# Altium schematic sheet 2 - Microcontroller.SchDoc
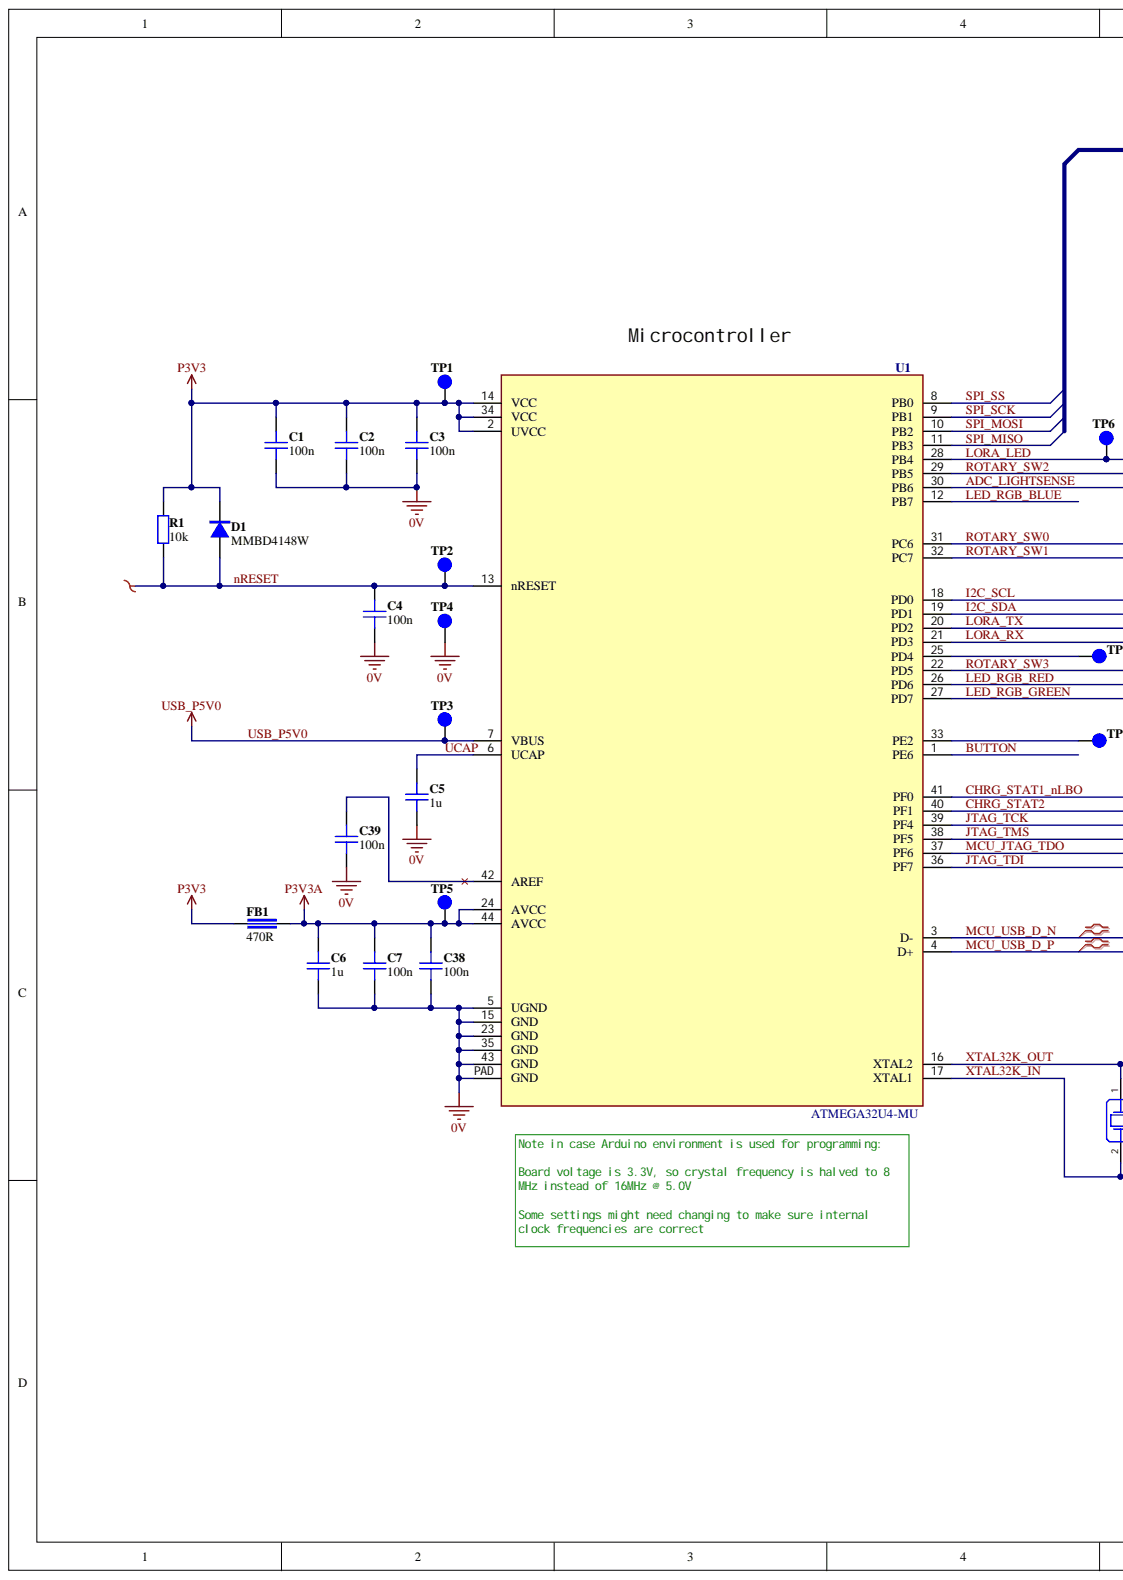
[diagram p0: 2 - Microcontroller.SchDoc, left]
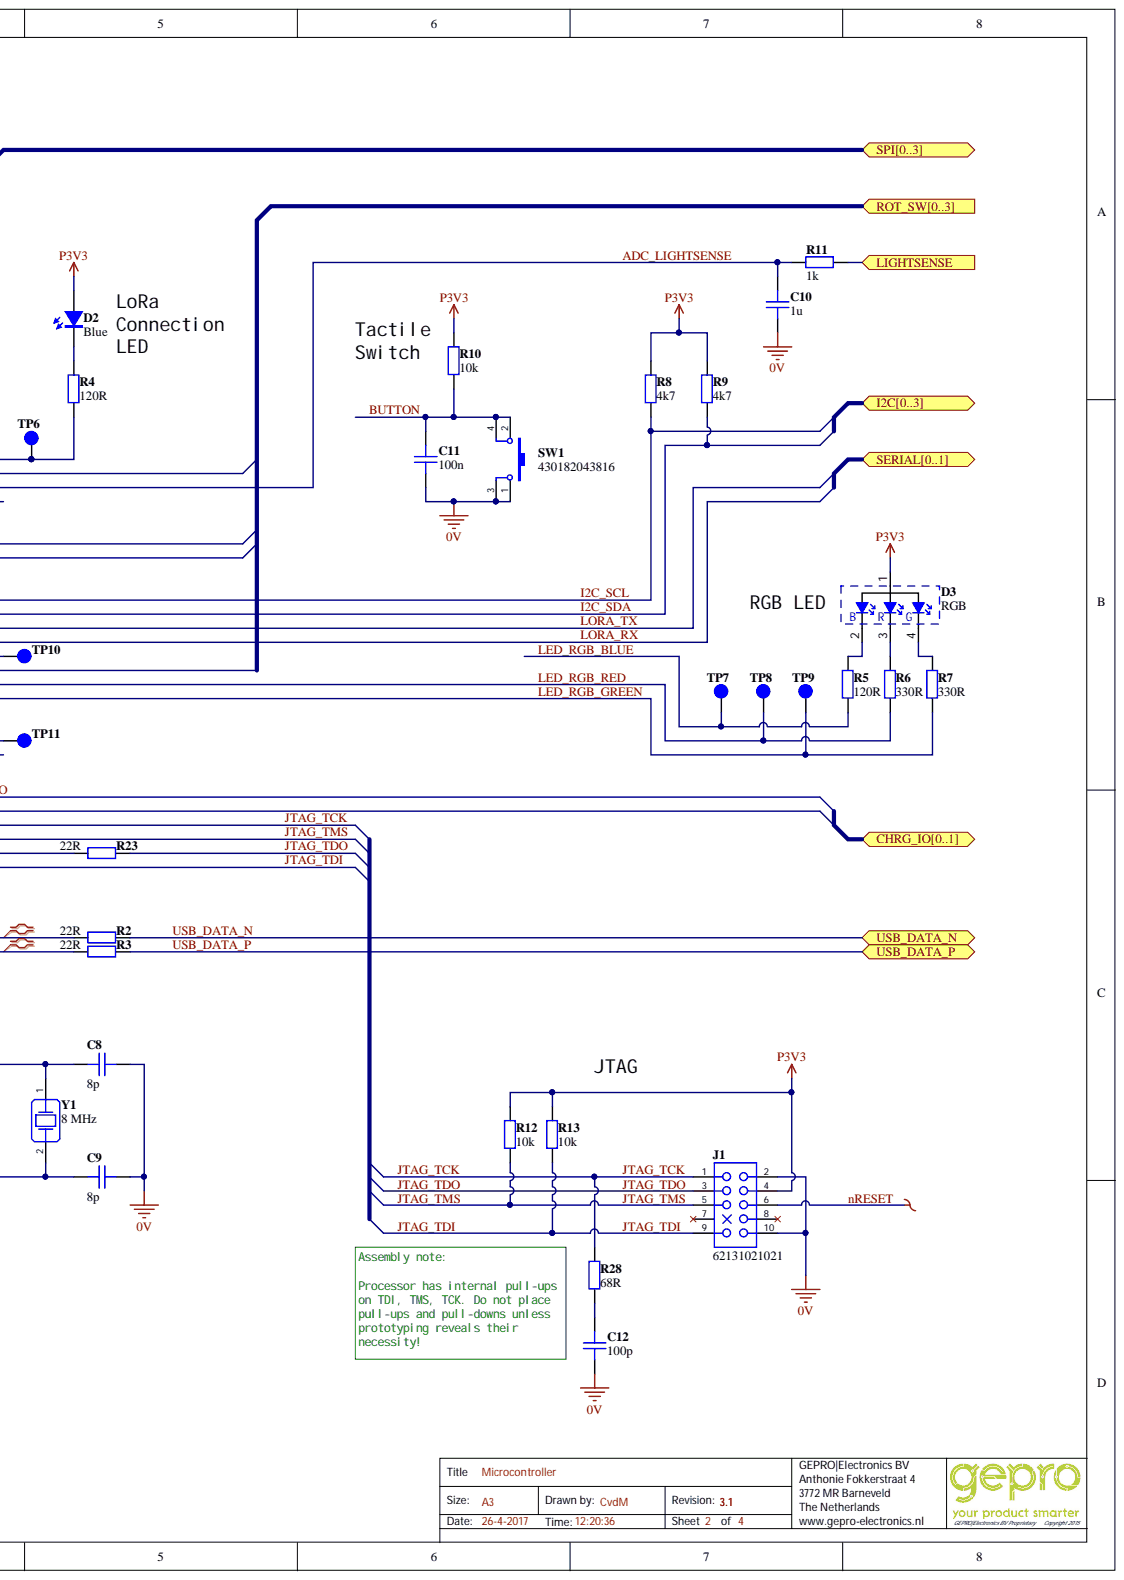
[diagram p1: 2 - Microcontroller.SchDoc, right]

## Parts
C1  100n  [CAPC1608X90N]
C2  100n  [CAPC1608X90N]
C3  100n  [CAPC1608X90N]
C4  100n  [CAPC1608X90N]
C5  1u  [CAPC1608X95N]
C6  1u  [CAPC1608X95N]
C7  100n  [CAPC1608X90N]
C8  8p  [CAPC1608X90N]
C9  8p  [CAPC1608X90N]
C10  1u  [CAPC1608X95N]
C11  100n  [CAPC1608X90N]
C12  100p  [CAPC1608X95N]
C38  100n  [CAPC1608X90N]
C39  100n  [CAPC1608X90N]
D1  MMBD4148W  [SODFL370X55-2N_DiodesInc_SOD123]
D2  Blue  [LEDSC80X160X80-2N_WurthElektronik_WL-SMCW_BLUE]
D3  RGB  Component Subtype: LED  [WURTH_WL-SFTW_150141M173100]
FB1  470R  [FB1608X100N]
J1  62131021021  [WurthElektronik_WR-PHD_62131021021]
R1  10k  [RESC1608X50N]
R2  22R  [RESC1608X50N]
R3  22R  [RESC1608X50N]
R4  120R  [RESC1608X50N]
R5  120R  [RESC1608X50N]
R6  330R  [RESC1608X50N]
R7  330R  [RESC1608X50N]
R8  4k7  [RESC1608X50N]
R9  4k7  [RESC1608X50N]
R10  10k  [RESC1608X50N]
R11  1k  [RESC1608X50N]
R12  10k  [RESC1608X50N]
R13  10k  [RESC1608X50N]
R23  22R  [RESC1608X50N]
R28  68R  [RESC1608X50N]
SW1  430182043816  [WurthElektronik_WS-TASV_4301x2xxx8x6]
TP1  Comment  [Testpoint_PAD_DIA_1mm]  (value not drawn)  (no BOM)
TP2  Comment  [Testpoint_PAD_DIA_1mm]  (value not drawn)  (no BOM)
TP3  Comment  [Testpoint_PAD_DIA_1mm]  (value not drawn)  (no BOM)
TP4  Comment  [Testpoint_PAD_DIA_1mm]  (value not drawn)  (no BOM)
TP5  Comment  [Testpoint_PAD_DIA_1mm]  (value not drawn)  (no BOM)
TP6  Comment  [Testpoint_PAD_DIA_1mm]  (value not drawn)  (no BOM)
TP7  Comment  [Testpoint_PAD_DIA_1mm]  (value not drawn)  (no BOM)
TP8  Comment  [Testpoint_PAD_DIA_1mm]  (value not drawn)  (no BOM)
TP9  Comment  [Testpoint_PAD_DIA_1mm]  (value not drawn)  (no BOM)
TP10  Comment  [Testpoint_PAD_DIA_1mm]  (value not drawn)  (no BOM)
TP11  Comment  [Testpoint_PAD_DIA_1mm]  (value not drawn)  (no BOM)
U1  ATMEGA32U4-MU  Component Type: Microcontroller  [QFN50P700X700X100-45T520X520N_Atmel_QFN44]
Y1  8 MHz  [NDK_NX5032GA]

## Ports
CHRG_IO0, CHRG_IO1, CHRG_IO[0..1], I2C0, I2C1, I2C2, I2C3, I2C[0..3], LIGHTSENSE, ROT_SW0, ROT_SW1, ROT_SW2, ROT_SW3, ROT_SW[0..3], SERIAL0, SERIAL1, SERIAL[0..1], SPI0, SPI1, SPI2, SPI3, SPI[0..3], USB_DATA_N, USB_DATA_P

## Nets
0V: C1.2 C2.2 C3.2 C4.2 C5.2 C6.2 C7.2 C8.2 C9.2 C10.2 C11.2 C12.2 C38.2 C39.2 J1.2 J1.10 SW1.1 SW1.3 TP4.1 U1.5(UGND) U1.15(GND) U1.23(GND) U1.35(GND) U1.43(GND) U1.PAD(GND)
P3V3: C1.1 C2.1 C3.1 D1.C D2.A D3.1 FB1.1 J1.4 R1.1 R8.1 R9.1 R10.1 R12.1 R13.1 TP1.1 U1.2(UVCC) U1.14(VCC) U1.34(VCC)
P3V3A: C6.1 C7.1 C38.1 FB1.2 TP5.1 U1.24(AVCC) U1.44(AVCC)
ADC_LIGHTSENSE: C10.1 R11.1 U1.30(PB6)
BUTTON: C11.1 R10.2 SW1.2 SW1.4 U1.1(PE6)
CHRG_STAT1_nLBO: U1.41(PF0)
CHRG_STAT2: U1.40(PF1)
I2C_SCL: R8.2 U1.18(PD0)
I2C_SDA: R9.2 U1.19(PD1)
JTAG_TCK: J1.1 R28.1 U1.39(PF4)
JTAG_TDI: J1.9 R13.2 U1.36(PF7)
JTAG_TDO: J1.3 R23.2
JTAG_TMS: J1.5 R12.2 U1.38(PF5)
LED_RGB_BLUE: R5.2 TP7.1 U1.12(PB7)
LED_RGB_GREEN: R7.2 TP9.1 U1.27(PD7)
LED_RGB_RED: R6.2 TP8.1 U1.26(PD6)
LIGHTSENSE: R11.2 ; port LIGHTSENSE
LORA_LED: R4.2 TP6.1 U1.28(PB4)
LORA_RX: U1.21(PD3)
LORA_TX: U1.20(PD2)
MCU_JTAG_TDO: R23.1 U1.37(PF6)
MCU_USB_D_N: R2.1 U1.3(D-)
MCU_USB_D_P: R3.1 U1.4(D+)
nRESET: C4.1 D1.A J1.6 R1.2 TP2.1 U1.13(nRESET)
ROTARY_SW0: U1.31(PC6)
ROTARY_SW1: U1.32(PC7)
ROTARY_SW2: U1.29(PB5)
ROTARY_SW3: U1.22(PD5)
SPI_MISO: U1.11(PB3)
SPI_MOSI: U1.10(PB2)
SPI_SCK: U1.9(PB1)
SPI_SS: U1.8(PB0)
UCAP: C5.1 U1.6(UCAP)
USB_DATA_N: R2.2 ; port USB_DATA_N
USB_DATA_P: R3.2 ; port USB_DATA_P
USB_P5V0: TP3.1 U1.7(VBUS)
XTAL32K_IN: C9.1 U1.17(XTAL1) Y1.2
XTAL32K_OUT: C8.1 U1.16(XTAL2) Y1.1
NetC12_1: C12.1 R28.2
NetC39_1: C39.1 U1.42(AREF)
NetD2_C: D2.C R4.1
NetD3_2: D3.2 R5.1
NetD3_3: D3.3 R6.1
NetD3_4: D3.4 R7.1
NetTP10_1: TP10.1 U1.25(PD4)
NetTP11_1: TP11.1 U1.33(PE2)
CHRG_IO[0..1]:
I2C[0..3]:
N00022:
ROT_SW[0..3]:
SERIAL[0..1]:
SPI[0..3]:

Not connected: J1 7 8

## Library data of the parts
C1-C4 C7 C11 C38 C39 = CAP_MLCC_1608_X7R_100nF_50V: Capacitor MLCC 0603 (1608 Metric) X7R 50V 100nF 10%; Manufacturer: No Preference; Manufacturer Part Number: Select according to description parameters; Package Technology: SMT; Polarized?: No; Capacitance: 100nF; Component Kind: Standard; Component Subtype: MLCC; Component Type: Capacitor; Dielectric Type: X7R; Operating Temperature Range: -55°C ~ 125°C; Package / Case: 0603 (1608 Metric); Pin Count: 2; RoHS Compliant?: Yes; Tolerance: ±10%; Voltage Rating: 50V; Library Name: KISS_IoT.SchLib
C5 C6 C10 = CAP_MLCC_1608_X7R_1u_10V: Capacitor MLCC 0603 (1608 Metric) X7R 10V 1uF 10%; Manufacturer: No Preference; Manufacturer Part Number: Select according to description parameters; Capacitance: 1µF; Package Technology: SMT; Polarized?: No; Component Kind: Standard; Component Subtype: MLCC; Component Type: Capacitor; Dielectric Type: X7R; Operating Temperature Range: -55°C ~ 125°C; Package / Case: 0603 (1608 Metric); Pin Count: 2; RoHS Compliant?: Yes; Tolerance: ±10%; Voltage Rating: 10V; Library Name: KISS_IoT.SchLib
C8 C9 = CAP_MLCC_1608_C0G_8pF_16V: Capacitor MLCC 0603 (1608 Metric) C0G (NP0) 16V 8pF 5%; Manufacturer: No Preference; Manufacturer Part Number: Select according to description parameters; Package Technology: SMT; Polarized?: No; Capacitance: 8pF; Component Kind: Standard; Component Subtype: MLCC; Component Type: Capacitor; Dielectric Type: C0G (NP0); Operating Temperature Range: -55°C ~ 125°C; Package / Case: 0603 (1608 Metric); Pin Count: 2; RoHS Compliant?: Yes; Tolerance: ±5%; Voltage Rating: 16V; Library Name: KISS_IoT.SchLib
C12 = CAP_MLCC_1608_X7R_100p_50V: Capacitor MLCC 0603 (1608 Metric) X7R 50V 100pF 10%; Manufacturer: No Preference; Manufacturer Part Number: Select according to description parameters; Package Technology: SMT; Polarized?: No; Capacitance: 100pF; Component Kind: Standard; Component Subtype: MLCC; Component Type: Capacitor; Dielectric Type: X7R; Operating Temperature Range: -55°C ~ 125°C; Package / Case: 0603 (1608 Metric); Pin Count: 2; RoHS Compliant?: Yes; Tolerance: ±10%; Voltage Rating: 50V; Library Name: KISS_IoT.SchLib
D1 = MMBD4148W: Diode Small Signal Vf=1.25V Vr=100V Id=150mA; Manufacturer: Diodes Incorporated; Manufacturer Part Number: 1N4148W-13-F; Current - Average Rectified (Io) (per Diode): 150mA; Current - Reverse Leakage @ Vr: 1µA @ 75V; Package Technology: SMT; Polarized?: Yes; Voltage - DC Reverse (Vr) (Max): 100V; Voltage - Forward (Vf) (Max) @ If: 1.25V @ 150mA; Component Kind: Standard; ComponentLink1Description: Data Sheet; ComponentLink1URL: http://www.diodes.com/_files/datasheets/ds30086.pdf; Component Subtype: Small Signal; Component Type: Diode; Operating Temperature Range: -65°C ~ 150°C; Package / Case: SOD-123; Pin Count: 2; RoHS Compliant?: Yes; Library Name: KISS_IoT.SchLib
D2 = 150060BS75000: LED 1608 3.2Vf 470nm Blue; Manufacturer: Würth Elektronik; Forward Voltage (Vf) (Typ): 3.2V; Lens Style/Size: Rectangle with Flat Top, 1.10mm x 0.70mm; Milicandella Rating: 145mcd; Package Technology: SMT; Polarized?: Yes; Color: Blue; Component Kind: Standard; ComponentLink1Description: Data Sheet; ComponentLink1URL: http://katalog.we-online.de/led/datasheet/150060BS75000.pdf; Component Subtype: LED; Component Type: Opto-electronics; Manufacturer Part Number: 150060BS75000; Operating Temperature Range: -40°C ~ 85°C; Package / Case: 0603 (1608 Metric); Pin Count: 2; RoHS Compliant?: Yes; Wavelength (Typ): 470nm; Library Name: KISS_IoT.SchLib
D3 = 150141M173100: LED 3528 2.0-3.2Vf Red Green Blue; Manufacturer: Würth Elektronik; Lens Style / Size: Round with Flat Top, 2.40mm; Package Technology: SMT; Polarized?: Yes; Component Kind: Standard; ComponentLink1Description: Data Sheet; ComponentLink1URL: http://katalog.we-online.de/led/datasheet/150141M173100.pdf; Component Type: Optoelectronics; Manufacturer Part Number: 150141M173100; Operating Temperature Range: -40°C ~ 85°C; Package / Case: 1411 (3528 Metric); Pin Count: 4; RoHS Compliant?: Yes; Library Name: KISS_IoT.SchLib
FB1 = 742792645: Chip Ferrite Bead 0603 (1608 Metric) 470R @ 100MHz 1A; Manufacturer: Würth Elektronik; DC Resistance (DCR): 200 mOhm; Impedance @ Frequency: 470 Ohm @ 100MHz; Package Technology: SMT; Polarized?: No; Component Kind: Standard; ComponentLink1Description: Data Sheet; ComponentLink1URL: http://katalog.we-online.com/pbs/datasheet/742792645.pdf; Component Subtype: Chip Ferrite Bead; Component Type: Filter; Current Rating: 1A; Manufacturer Part Number: 742792645; Operating Temperature Range: -55°C ~ 125°C; Package / Case: 0603 (1608 Metric); Pin Count: 2; RoHS Compliant?: Yes; Library Name: KISS_IoT.SchLib
J1 = ARM_Cortex_HDR2X5: Header 2x5 Male Receptable Vertical 1.27mm Pitch 1A 50V WR-PHD Series; Manufacturer: Würth Elektronik; Number of Positions (per Row): 5; Package Technology: SMT; Polarized?: Yes; Shrouded?: No; Voltage Rating: 50VAC; Component Kind: Standard; ComponentLink1Description: Data Sheet; ComponentLink1URL: http://katalog.we-online.de/em/datasheet/6213xx21021.pdf; Component Subtype: Header; Component Type: Connector; Current Rating: 1A; Gender: Male; Manufacturer Part Number: 62131021021; Number of Rows: 2; Orientation: Vertical; Pin Count: 10; Pitch: 1.27mm; RoHS Compliant?: Yes; Row Spacing: 1.27mm; Series: M50; Library Name: KISS_IoT.SchLib
R1 R10 R12 R13 = (no symbol name): Resistor 0603 (1608 Metric) Thick Film 100mW 10k 1%; Manufacturer: No Preference; Manufacturer Part Number: Select according to description parameters; Component Subtype: Chip SMT; Package Technology: SMT; Polarized Footprint?: No; Resistance: 10kΩ; Temperature Coefficient: ±100 ppm/K; Unique ID: GCD_0001470; Voltage Rating: 75V; Component Kind: Standard; Component Type: Resistor; Operating Temperature Range: -55°C ~ 155°C; Package / Case: 0603 (1608 Metric); Pin Count: 2; Power Rating: 100mW; Resistor Type: Thick Film; RoHS Compliant?: Yes; Tolerance: 1%; Library Name: KISS_IoT.SchLib
R2 R3 R23 = (no symbol name): Resistor 0603 (1608 Metric) Thick Film 100mW 22R 1%; Manufacturer: No Preference; Manufacturer Part Number: Select according to description parameters; Component Subtype: Chip SMT; Package Technology: SMT; Polarized Footprint?: No; Resistance: 22Ω; Temperature Coefficient: ±100 ppm/K; Voltage Rating: 75V; Component Kind: Standard; Component Type: Resistor; Operating Temperature Range: -55°C ~ 155°C; Package / Case: 0603 (1608 Metric); Pin Count: 2; Power Rating: 100mW; Resistor Type: Thick Film; RoHS Compliant?: Yes; Tolerance: 1%; Library Name: KISS_IoT.SchLib
R4 R5 = (no symbol name): Resistor 0603 (1608 Metric) Thick Film 100mW 120R 1%; Manufacturer: No Preference; Manufacturer Part Number: Select according to description parameters; Component Subtype: Chip SMT; Package Technology: SMT; Polarized Footprint?: No; Resistance: 120Ω; Temperature Coefficient: ±100 ppm/K; Voltage Rating: 75V; Component Kind: Standard; Component Type: Resistor; Operating Temperature Range: -55°C ~ 155°C; Package / Case: 0603 (1608 Metric); Pin Count: 2; Power Rating: 100mW; Resistor Type: Thick Film; RoHS Compliant?: Yes; Tolerance: 1%; Library Name: KISS_IoT.SchLib
R6 R7 = (no symbol name): Resistor 0603 (1608 Metric) Thick Film 100mW 330R 1%; Manufacturer: No Preference; Manufacturer Part Number: Select according to description parameters; Component Subtype: Chip SMT; Package Technology: SMT; Polarized Footprint?: No; Resistance: 330Ω; Temperature Coefficient: ±100 ppm/K; Voltage Rating: 75V; Component Kind: Standard; Component Type: Resistor; Operating Temperature Range: -55°C ~ 155°C; Package / Case: 0603 (1608 Metric); Pin Count: 2; Power Rating: 100mW; Resistor Type: Thick Film; RoHS Compliant?: Yes; Tolerance: 1%; Library Name: KISS_IoT.SchLib
R8 R9 = (no symbol name): Resistor 0603 (1608 Metric) Thick Film 100mW 4k7 1%; Manufacturer: No Preference; Manufacturer Part Number: Select according to description parameters; Component Subtype: Chip SMT; Package Technology: SMT; Polarized Footprint?: No; Resistance: 4.7kΩ; Temperature Coefficient: ±100 ppm/K; Voltage Rating: 75V; Component Kind: Standard; Component Type: Resistor; Operating Temperature Range: -55°C ~ 155°C; Package / Case: 0603 (1608 Metric); Pin Count: 2; Power Rating: 100mW; Resistor Type: Thick Film; RoHS Compliant?: Yes; Tolerance: 1%; Library Name: KISS_IoT.SchLib
R11 = (no symbol name): Resistor 0603 (1608 Metric) Thick Film 100mW 1k 1%; Manufacturer: No Preference; Manufacturer Part Number: Select according to description parameters; Component Subtype: Chip SMT; Package Technology: SMT; Polarized Footprint?: No; Resistance: 1kΩ; Temperature Coefficient: ±100 ppm/K; Voltage Rating: 75V; Component Kind: Standard; Component Type: Resistor; Operating Temperature Range: -55°C ~ 155°C; Package / Case: 0603 (1608 Metric); Pin Count: 2; Power Rating: 100mW; Resistor Type: Thick Film; RoHS Compliant?: Yes; Tolerance: 1%; Library Name: KISS_IoT.SchLib
R28 = (no symbol name): Resistor 0603 (1608 Metric) Thick Film 100mW 68R 1%; Manufacturer: No Preference; Manufacturer Part Number: Select according to description parameters; Component Subtype: Chip SMT; Package Technology: SMT; Polarized Footprint?: No; Resistance: 68Ω; Temperature Coefficient: ±100 ppm/K; Voltage Rating: 75V; Component Kind: Standard; Component Type: Resistor; Operating Temperature Range: -55°C ~ 155°C; Package / Case: 0603 (1608 Metric); Pin Count: 2; Power Rating: 100mW; Resistor Type: Thick Film; RoHS Compliant?: Yes; Tolerance: 1%; Library Name: KISS_IoT.SchLib
SW1 = 430182043816: Switch Tactile SMT 6.2x6.2mm 12V 50mA 100mR; Manufacturer: Würth Elektronik; Circuit: SPST-NO; Contact Rating @ Voltage: 0.05A @ 12VDC; Polarized?: No; Component Kind: Standard; ComponentLink1Description: Data Sheet; ComponentLink1URL: http://katalog.we-online.com/em/datasheet/4301x2xxx8x6.pdf; Component Subtype: Tactile; Component Type: Switch; Manufacturer Part Number: 430182043816; Operating Temperature Range: -40°C ~ 85°C; Package / Case: SMT Gull Wing; Package Technology: SMT; Pin Count: 4; RoHS Compliant?: Yes; Library Name: KISS_IoT.SchLib
TP1-TP11 = TestPoint_SMD_Pad: Test Point round pad 1mm, 2mm ring; Component Subtype: Copper Pad Round; Component Type: Test Point; Library Name: KISS_IoT.SchLib
U1 = ATMEGA32U4-MU: AVR ATmega Microcontroller IC 8-Bit 16MHz 32KB (16K x 16) FLASH 44-QFN (7x7); Manufacturer: Atmel; Number of I/O: 26; Package Technology: SMT; Polarized: Yes; Supply Voltage: 2.7 V ~ 5.5 V; Component Kind: Standard; ComponentLink1Description: Data Sheet; ComponentLink1URL: http://www.atmel.com/Images/Atmel-7766-8-bit-AVR-ATmega16U4-32U4_Datasheet.pdf; Component Subtype: AVR; Core Frequency (Max): 16MHz; Manufacturer Part Number: ATMEGA32U4-MU; Operating Temperature Range: -40°C ~ 85°C; Package / Case: 44-VFQFN Exposed Pad; Pin Count: 44; RoHS Compliant?: Yes; Library Name: KISS_IoT.SchLib
Y1 = NX5032GA-8.000M-STD-CSU-1: Crystal SMT 8MHz 50ppm 8pF; Manufacturer: NDK; Polarized?: No; Component Kind: Standard; ComponentLink1Description: Data Sheet; ComponentLink1URL: http://www.ndk.com/images/products/catalog/c_NX5032GA-STD-CSU-2_e.pdf; Component Subtype: Crystal; Component Type: Oscillators; Manufacturer Part Number: NX5032GA-8.000M-STD-CSU-1; Operating Temperature Range: -10°C ~ 70°C; Package / Case: 2-SMD; Package Technology: SMT; Pin Count: 2; RoHS Compliant?: Yes; Library Name: KISS_IoT.SchLib
